# Altium schematic sheet 加热.SchDoc
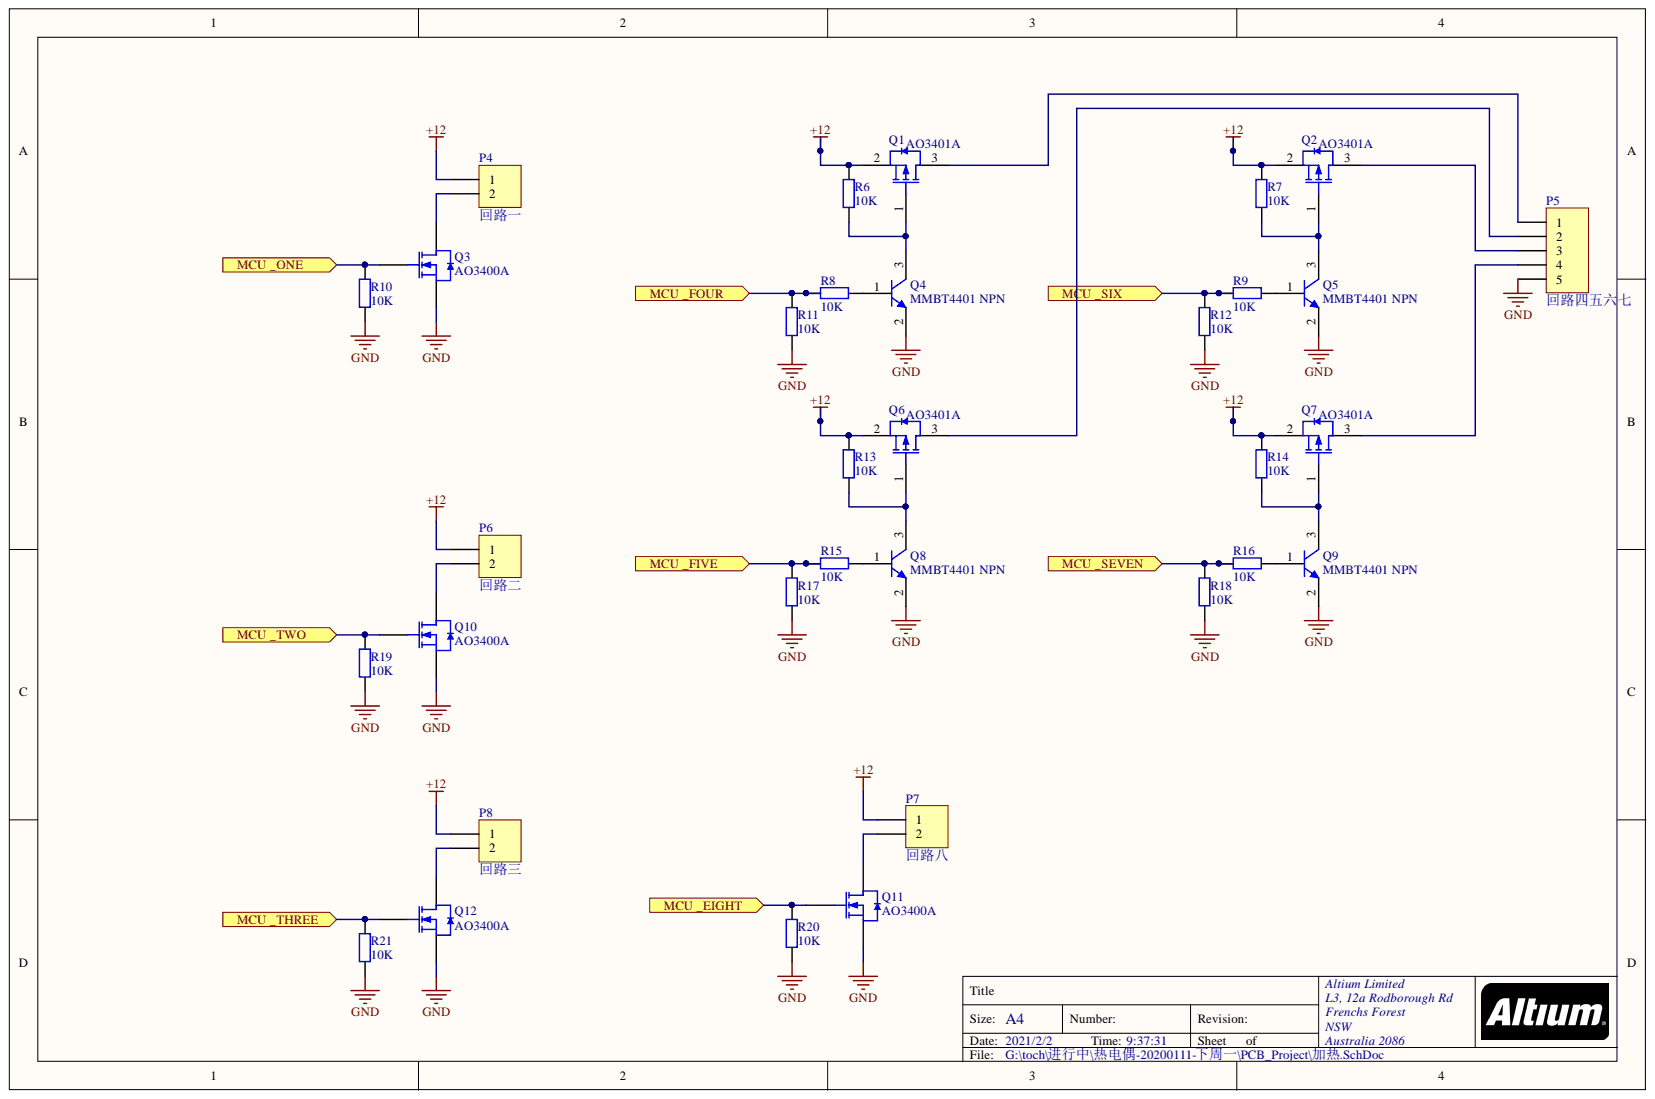
[diagram p0: 加热.SchDoc]

## Parts
P4  回路一  [CON1.5_2pin]
P5  回路四五六七  [CON1.5_5pin]
P6  回路二  [CON1.5_2pin]
P7  回路八  [CON1.5_2pin]
P8  回路三  [CON1.5_2pin]
Q1  AO3401A  [SOT-23-3L]
Q2  AO3401A  [SOT-23-3L]
Q3  AO3400A  [SOT-23-3L]
Q4  MMBT4401 NPN  [SOT-23(SOT-23-3)]
Q5  MMBT4401 NPN  [SOT-23(SOT-23-3)]
Q6  AO3401A  [SOT-23-3L]
Q7  AO3401A  [SOT-23-3L]
Q8  MMBT4401 NPN  [SOT-23(SOT-23-3)]
Q9  MMBT4401 NPN  [SOT-23(SOT-23-3)]
Q10  AO3400A  [SOT-23-3L]
Q11  AO3400A  [SOT-23-3L]
Q12  AO3400A  [SOT-23-3L]
R6  10K  [0603_R]
R7  10K  [0603_R]
R8  10K  [0603_R]
R9  10K  [0603_R]
R10  10K  [0603_R]
R11  10K  [0603_R]
R12  10K  [0603_R]
R13  10K  [0603_R]
R14  10K  [0603_R]
R15  10K  [0603_R]
R16  10K  [0603_R]
R17  10K  [0603_R]
R18  10K  [0603_R]
R19  10K  [0603_R]
R20  10K  [0603_R]
R21  10K  [0603_R]

## Ports
MCU _EIGHT, MCU _FIVE, MCU _FOUR, MCU _ONE, MCU _SEVEN, MCU _SIX, MCU _THREE, MCU _TWO

## Nets
+12: P4.1 P6.1 P7.1 P8.1 Q1.2 Q2.2 Q6.2 Q7.2 R6.1 R7.1 R13.1 R14.1
GND: P5.5 Q3.2 Q4.2 Q5.2 Q8.2 Q9.2 Q10.2 Q11.2 Q12.2 R10.2 R11.2 R12.2 R17.2 R18.2 R19.2 R20.2 R21.2
MCU _EIGHT: Q11.1 R20.1 ; port MCU _EIGHT
MCU _FIVE: R15.1 R17.1 ; port MCU _FIVE
MCU _FOUR: R8.1 R11.1 ; port MCU _FOUR
MCU _ONE: Q3.1 R10.1 ; port MCU _ONE
MCU _SEVEN: R16.1 R18.1 ; port MCU _SEVEN
MCU _SIX: R9.1 R12.1 ; port MCU _SIX
MCU _THREE: Q12.1 R21.1 ; port MCU _THREE
MCU _TWO: Q10.1 R19.1 ; port MCU _TWO
NetP4_2: P4.2 Q3.3
NetP5_1: P5.1 Q1.3
NetP5_2: P5.2 Q6.3
NetP5_3: P5.3 Q2.3
NetP5_4: P5.4 Q7.3
NetP6_2: P6.2 Q10.3
NetP7_2: P7.2 Q11.3
NetP8_2: P8.2 Q12.3
NetQ1_1: Q1.1 Q4.3 R6.2
NetQ2_1: Q2.1 Q5.3 R7.2
NetQ4_1: Q4.1 R8.2
NetQ5_1: Q5.1 R9.2
NetQ6_1: Q6.1 Q8.3 R13.2
NetQ7_1: Q7.1 Q9.3 R14.2
NetQ8_1: Q8.1 R15.2
NetQ9_1: Q9.1 R16.2

## Library data of the parts
P4 P6-P8 = Header 2: Header, 2-Pin; Component Kind: Standard; LatestRevisionDate: 17-Jul-2002; LatestRevisionNote: Re-released for DXP Platform.; Library Name: Miscellaneous Connectors.IntLib; Signal Integrity: Connector; Publisher: Altium Limited
P5 = Header 5: Header, 5-Pin; Component Kind: Standard; LatestRevisionDate: 17-Jul-2002; LatestRevisionNote: Re-released for DXP Platform.; Library Name: Miscellaneous Connectors.IntLib; Signal Integrity: Connector; Publisher: Altium Limited
Q1 Q2 Q6 Q7 = AO3401A P道沟 X13A15 SOT-23: MOS,-30V,4A,60mR,1.4W; Component Kind: Standard; ComponentLink1Description: 供应商链接; ComponentLink1URL: http://www.szlcsc.com/product/details_15801.html; Library Name: Miscellaneous Devices LC.IntLib; Package: SOT-23; Supplier: LC; SuppliersPartNumber: C15127
Q3 Q10-Q12 = AO3400A N道沟 X0YV1T SOT-23: MOS,30V,5.8A,33mR,1.4W; Component Kind: Standard; ComponentLink1Description: 供应商链接; ComponentLink1URL: http://www.szlcsc.com/product/details_21629.html; Library Name: Miscellaneous Devices LC.IntLib; Package: SOT-23; Supplier: LC; SuppliersPartNumber: C20917
Q4 Q5 Q8 Q9 = SOT-23-3 MMBT4401: NPN 三极管; Component Kind: Standard; Library Name: Miscellaneous Devices LC.IntLib
R6-R21 = 0603 10KΩ (1002) 1%: 贴片电阻; Component Kind: Standard; ComponentLink1Description: 供应商链接; ComponentLink1URL: http://www.szlcsc.com/product/details_26547.html; Library Name: Miscellaneous Devices LC.IntLib; Package: 0603; Supplier: LC; SuppliersPartNumber: C25804
